# Altium schematic sheet 07_microSD.SchDoc
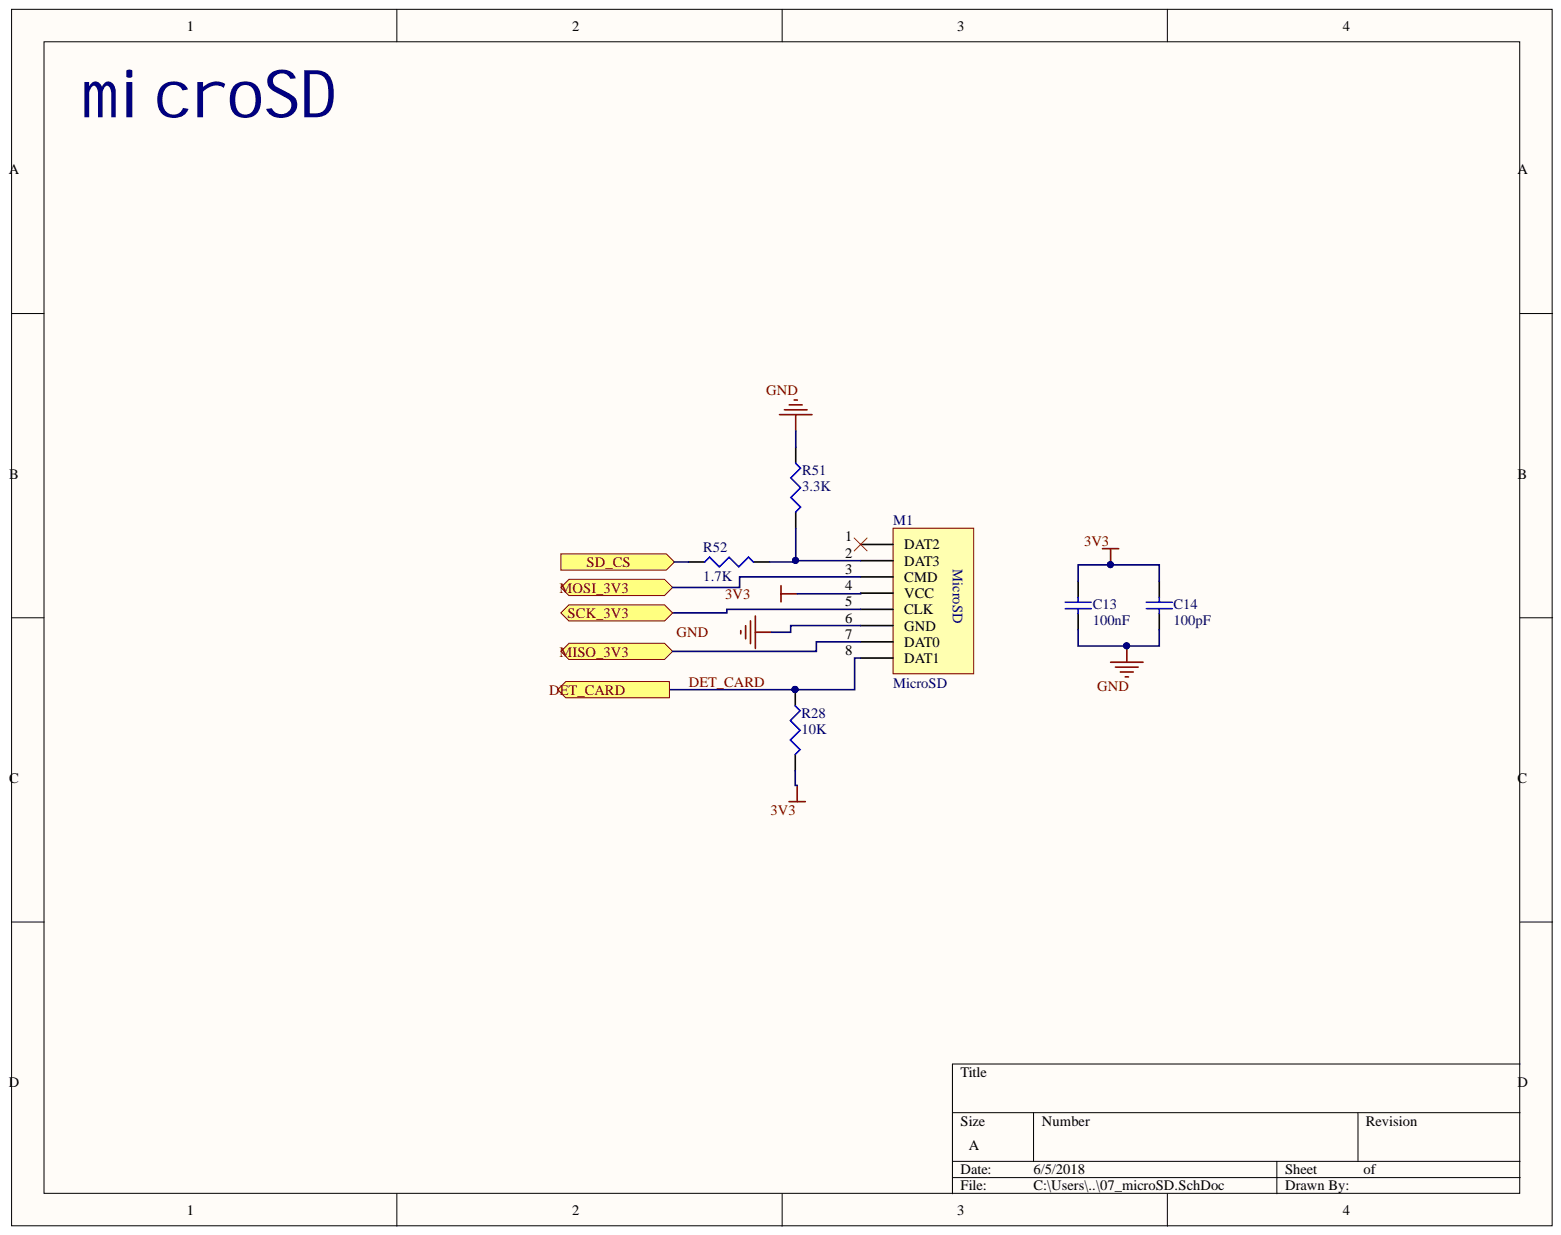
[diagram p0: 07_microSD.SchDoc]

## Parts
C13  100nF  [CAP_0603_SMD]
C14  100pF  [CAP_0603_SMD]
M1  MicroSD  [MicroSD_DM3AT]
R28  10K  [RES_0603_SMD]
R51  3.3K  [RES_0603_SMD]
R52  1.7K  [RES_0603_SMD]

## Ports
DET_CARD, MISO_3V3, MOSI_3V3, SCK_3V3, SD_CS

## Nets
3V3: C13.1 C14.1 M1.4(VCC) R28.2
GND: C13.2 C14.2 M1.6(GND) R51.1
DET_CARD: M1.8(DAT1) R28.1 ; port DET_CARD
MISO_3V3: M1.7(DAT0) ; port MISO_3V3
MOSI_3V3: M1.3(CMD) ; port MOSI_3V3
SCK_3V3: M1.5(CLK) ; port SCK_3V3
SD_CS: R52.1 ; port SD_CS
NetM1_2: M1.2(DAT3) R51.2 R52.2

Not connected: M1 1(DAT2)

## Library data of the parts
C13 C14 = Cap Semi: Capacitor (Semiconductor SIM Model); Component Kind: Standard; LatestRevisionDate: 29-May-2009; LatestRevisionNote: IPC-7351 Footprint Added.; PackageDescription: Chip Capacitor; PackageReference: SMD 0805; Published: 8-Jun-2000; Signal Integrity: Cap Semi; Simulation: CAP; Library Name: C:\Users\Public\Documents\Altium\AD16\Library\Miscellaneous Devices.IntLib; Publisher: Altium Limited
  C13: Value: 100nF
  C14: Value: 100pF
R28 R51 R52 = Res3: Resistor; Component Kind: Standard; LatestRevisionDate: 29-May-2009; LatestRevisionNote: IPC-7351 Footprint Added.; PackageDescription: Chip Resistor; PackageReference: SMD 0805; Published: 8-Jun-2000; Signal Integrity: Res; Simulation: RESISTOR; Library Name: C:\Users\Public\Documents\Altium\AD16\Library\Miscellaneous Devices.IntLib; Publisher: Altium Limited
  R28: Value: 10K
  R51: Value: 3.3K
  R52: Value: 1.7K
M1 = MicroSD: Component Kind: Standard; Library Name: ZYF_Connector.SchLib
